# Altium schematic sheet DE-120824.SchDoc(DE-120824)
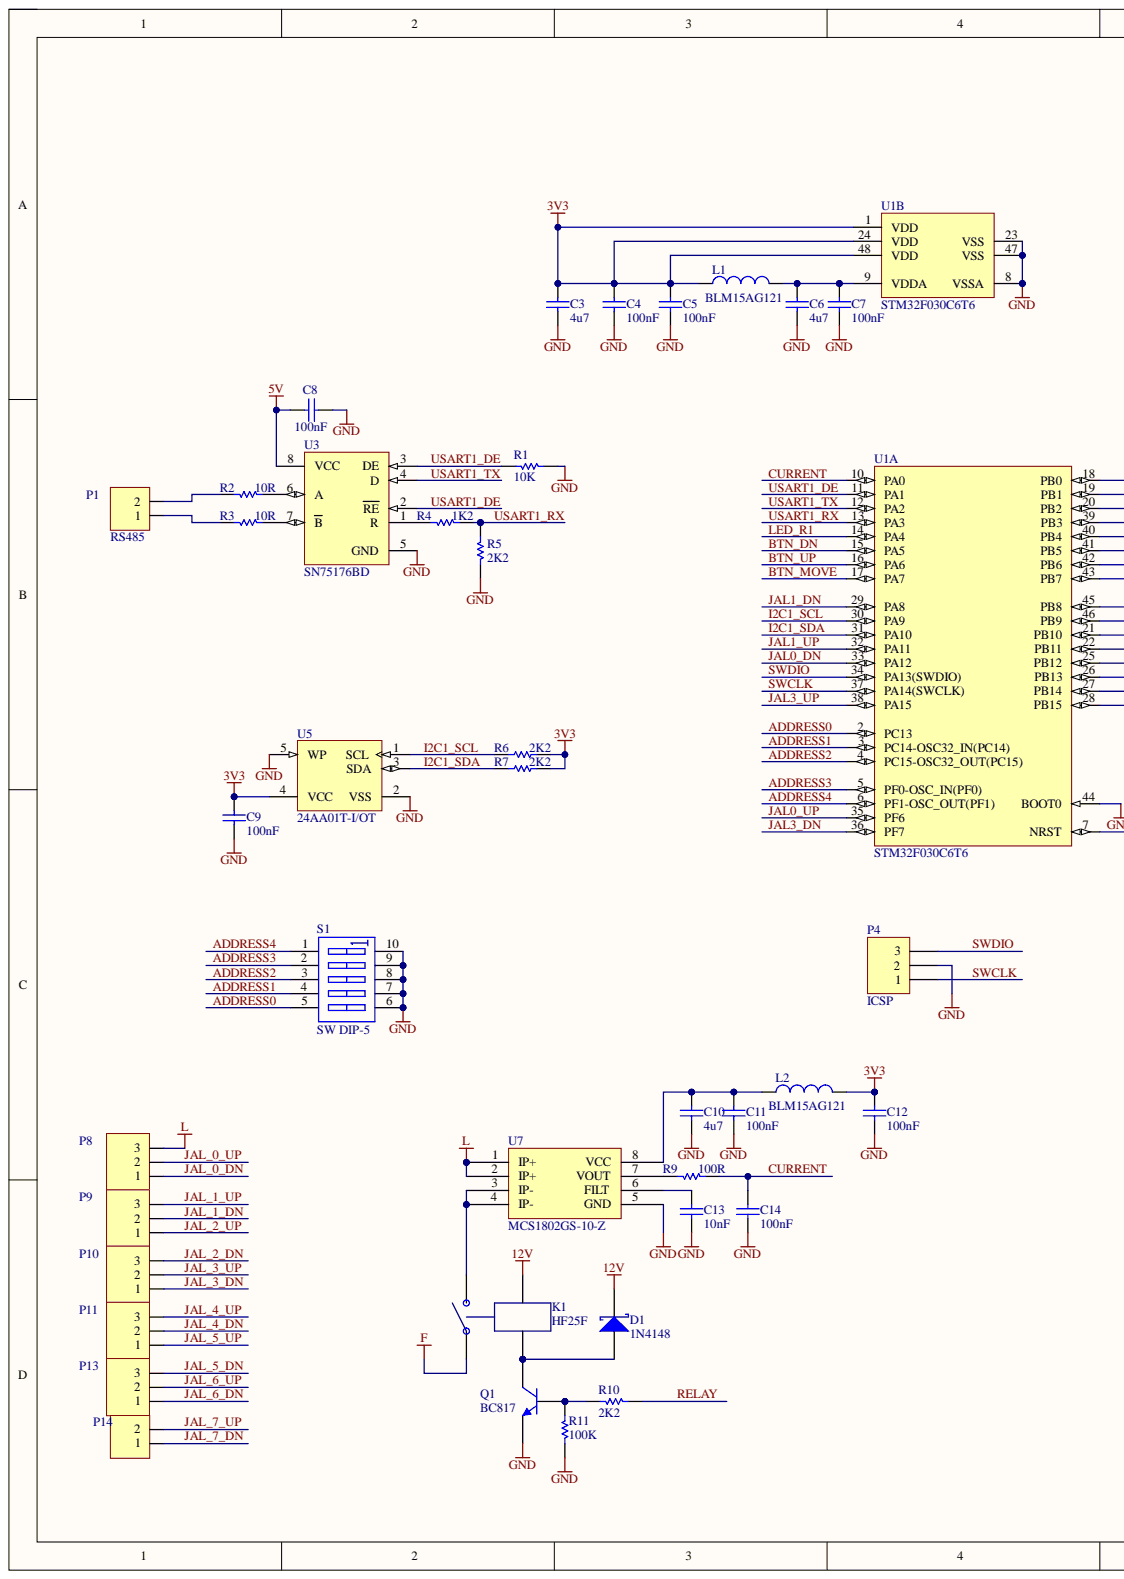
[diagram p0: DE-120824.SchDoc, left]
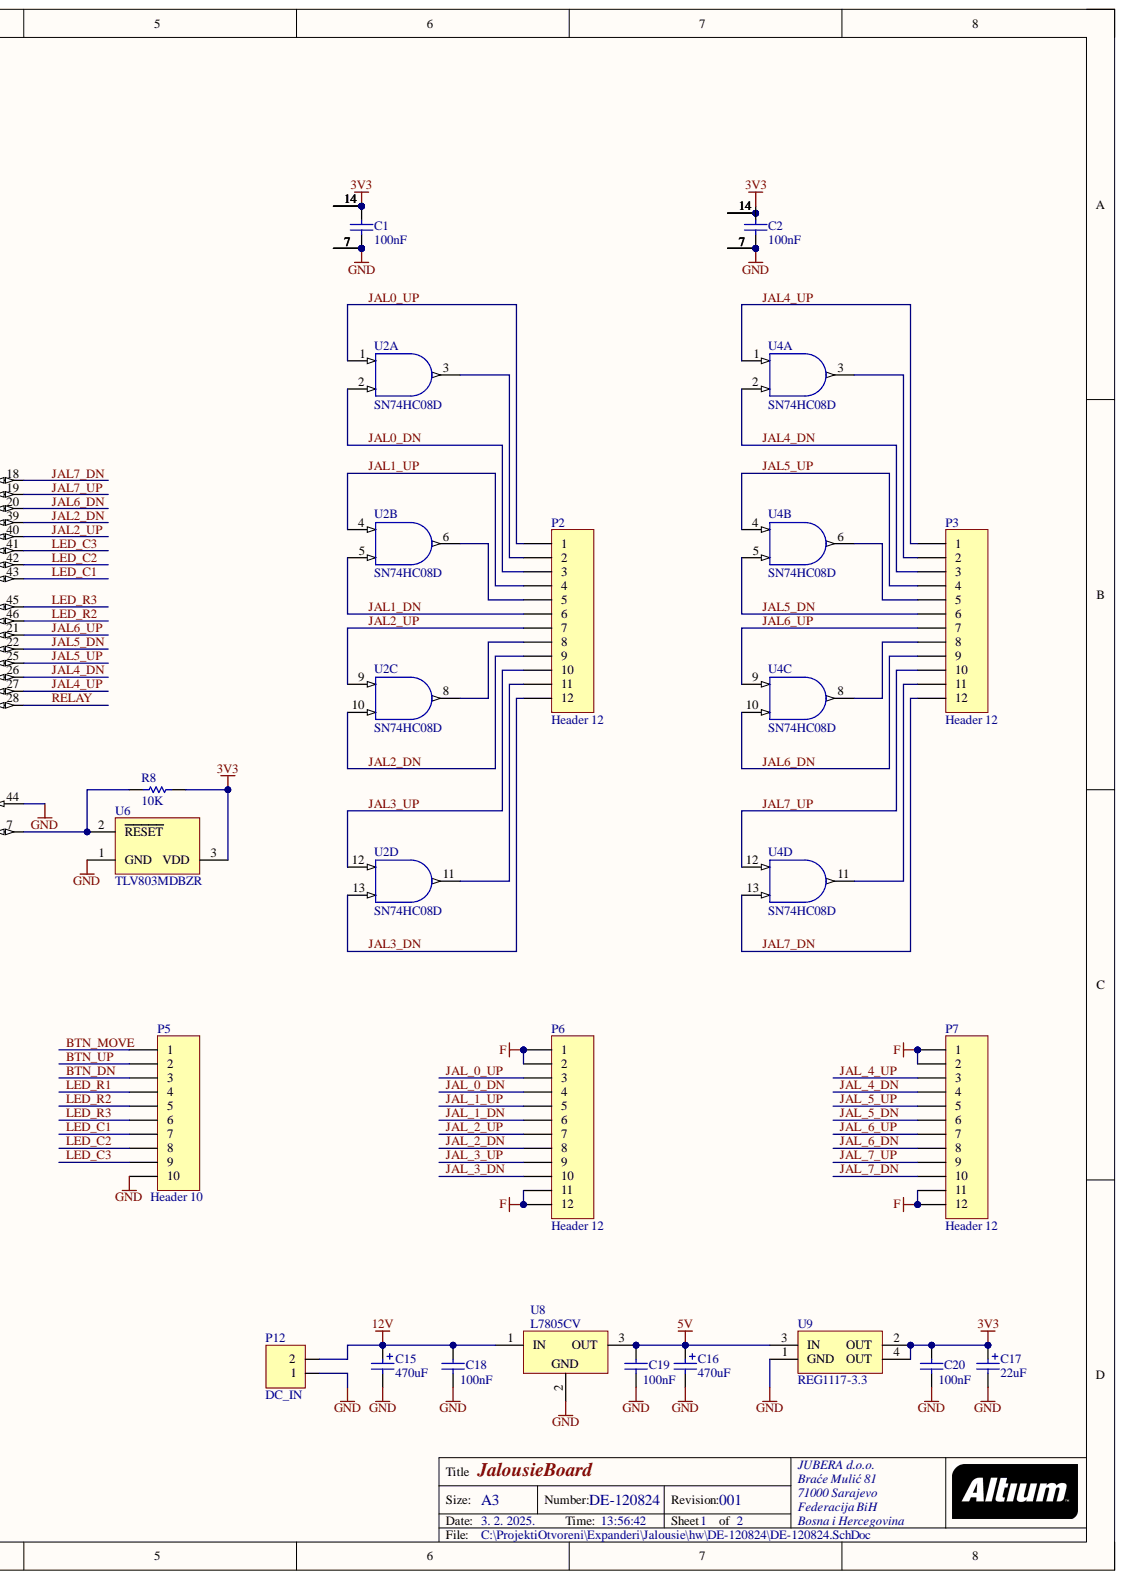
[diagram p1: DE-120824.SchDoc, right]

## Parts
C1  value 100nF  [0603]  (hidden comment: C0603)
C2  value 100nF  [0603]  (hidden comment: C0603)
C3  value 4u7  [0805]  (hidden comment: C0805)
C4  value 100nF  [0603]  (hidden comment: C0603)
C5  value 100nF  [0603]  (hidden comment: C0603)
C6  value 4u7  [0805]  (hidden comment: C0805)
C7  value 100nF  [0603]  (hidden comment: C0603)
C8  100nF  [0603]
C9  value 100nF  [0603]  (hidden comment: C0603)
C10  value 4u7  [0805]  (hidden comment: C0805)
C11  value 100nF  [0603]  (hidden comment: C0603)
C12  value 100nF  [0603]  (hidden comment: C0603)
C13  value 10nF  [0603]  (hidden comment: C0603)
C14  value 100nF  [0603]  (hidden comment: C0603)
C15  470uF  [CAPPR5-10X12.5]
C16  470uF  [CAPPR5-10X12.5]
C17  22uF  [CAPPR2-5X11]
C18  100nF  [0603]
C19  100nF  [0603]
C20  100nF  [0603]
D1  1N4148  [SOD323]
K1  HF25F  [HF25F]
L1  BLM15AG121  [0402-A]
L2  BLM15AG121  [0402-A]
P1  RS485  [TERMINAL BLOK 2]
P2  Header 12  [HDR1X12]
P3  Header 12  [HDR1X12]
P4  ICSP  [HDR1X3]
P5  Header 10  [HDR1X10]
P6  Header 12  [HDR1X12]
P7  Header 12  [HDR1X12]
P8  (no value)  [TERMINAL BLOK 3]
P9  (no value)  [TERMINAL BLOK 3]
P10  (no value)  [TERMINAL BLOK 3]
P11  (no value)  [TERMINAL BLOK 3]
P12  DC_IN  [TERMINAL BLOK 2]
P13  (no value)  [TERMINAL BLOK 3]
P14  (no value)  [TERMINAL BLOK 2]
Q1  BC817  [SOT23_N]
R1  10K  [ERA-0603]
R2  10R  [ERA-0603]
R3  10R  [ERA-0603]
R4  1K2  [ERA-0603]
R5  2K2  [ERA-0603]
R6  2K2  [ERA-0603]
R7  2K2  [ERA-0603]
R8  10K  [ERA-0603]
R9  100R  [ERA-0603]
R10  2K2  [ERA-0603]
R11  100K  [ERA-0603]
S1  SW DIP-5  [N10A]
U1  STM32F030C6T6  [STM-LQFP48_N]  (parts A,B)
U2  SN74HC08D  [D014_N]  (parts A,B,C,D)
U3  SN75176BD  [D008_N]
U4  SN74HC08D  [D014_N]  (parts A,B,C,D)
U5  24AA01T-I/OT  [SOT-23-OT5_N]
U6  TLV803MDBZR  [DBZ3_N]
U7  MCS1802GS-10-Z  [SOIC-SN8_N]
U8  L7805CV  [TO220]
U9  REG1117-3.3  [ZZ311]

## Nets
3V3: C1.1 C2.1 C3.2 C4.2 C5.2 C9.2 C12.2 C17.1 C20.2 L1.1 L2.2 R6.2 R7.2 R8.2 U1.1(VDD) U1.24(VDD) U1.48(VDD) U2.14 U5.4(VCC) U6.3 U9.2(OUT) U9.4(OUT)
5V: C8.1 C16.1 C19.2 U3.8(VCC) U8.3 U9.3(IN)
12V: C15.1 C18.2 D1.1 K1.2 P12.2 U8.1
F: K1.3 P6.1 P6.2 P6.11 P6.12 P7.1 P7.2 P7.11 P7.12
GND: C1.2 C2.2 C3.1 C4.1 C5.1 C6.1 C7.1 C8.2 C9.1 C10.1 C11.1 C12.1 C13.1 C14.1 C15.2 C16.2 C17.2 C18.1 C19.1 C20.1 P4.2 P5.10 P12.1 Q1.2 R1.1 R5.2 R11.1 S1.6 S1.7 S1.8 S1.9 S1.10 U1.8(VSSA) U1.23(VSS) U1.44(BOOT0) U1.47(VSS) U2.7 U3.5(GND) U4.7 U5.2(VSS) U5.5(WP) U6.1 U7.5(GND) U8.2 U9.1(GND)
L: P8.3 U7.1(IP+) U7.2(IP+)
ADDRESS0: S1.5 U1.2(PC13)
ADDRESS1: S1.4 U1.3(PC14-OSC32_IN(PC14))
ADDRESS2: S1.3 U1.4(PC15-OSC32_OUT(PC15))
ADDRESS3: S1.2 U1.5(PF0-OSC_IN(PF0))
ADDRESS4: S1.1 U1.6(PF1-OSC_OUT(PF1))
BTN_DN: P5.3 U1.15(PA5)
BTN_MOVE: P5.1 U1.17(PA7)
BTN_UP: P5.2 U1.16(PA6)
CURRENT: C14.2 R9.1 U1.10(PA0)
I2C1_SCL: R6.1 U1.30(PA9) U5.1(SCL)
I2C1_SDA: R7.1 U1.31(PA10) U5.3(SDA)
JAL0_DN: P2.3 U1.33(PA12) U2.2
JAL0_UP: P2.1 U1.35(PF6) U2.1
JAL1_DN: P2.6 U1.29(PA8) U2.5
JAL1_UP: P2.4 U1.32(PA11) U2.4
JAL2_DN: P2.9 U1.39(PB3) U2.10
JAL2_UP: P2.7 U1.40(PB4) U2.9
JAL3_DN: P2.12 U1.36(PF7) U2.13
JAL3_UP: P2.10 U1.38(PA15) U2.12
JAL4_DN: P3.3 U1.26(PB13) U4.2
JAL4_UP: P3.1 U1.27(PB14) U4.1
JAL5_DN: P3.6 U1.22(PB11) U4.5
JAL5_UP: P3.4 U1.25(PB12) U4.4
JAL6_DN: P3.9 U1.20(PB2) U4.10
JAL6_UP: P3.7 U1.21(PB10) U4.9
JAL7_DN: P3.12 U1.18(PB0) U4.13
JAL7_UP: P3.10 U1.19(PB1) U4.12
JAL_0_DN: P6.4 P8.1
JAL_0_UP: P6.3 P8.2
JAL_1_DN: P6.6 P9.2
JAL_1_UP: P6.5 P9.3
JAL_2_DN: P6.8 P10.3
JAL_2_UP: P6.7 P9.1
JAL_3_DN: P6.10 P10.1
JAL_3_UP: P6.9 P10.2
JAL_4_DN: P7.4 P11.2
JAL_4_UP: P7.3 P11.3
JAL_5_DN: P7.6 P13.3
JAL_5_UP: P7.5 P11.1
JAL_6_DN: P7.8 P13.1
JAL_6_UP: P7.7 P13.2
JAL_7_DN: P7.10 P14.1
JAL_7_UP: P7.9 P14.2
LED_C1: P5.7 U1.43(PB7)
LED_C2: P5.8 U1.42(PB6)
LED_C3: P5.9 U1.41(PB5)
LED_R1: P5.4 U1.14(PA4)
LED_R2: P5.5 U1.46(PB9)
LED_R3: P5.6 U1.45(PB8)
RELAY: R10.2 U1.28(PB15)
SWCLK: P4.1 U1.37(PA14(SWCLK))
SWDIO: P4.3 U1.34(PA13(SWDIO))
USART1_DE: R1.2 U1.11(PA1) U3.2(RE) U3.3(DE)
USART1_RX: R4.1 R5.1 U1.13(PA3)
USART1_TX: U1.12(PA2) U3.4(D)
NetC6_2: C6.2 C7.2 L1.2 U1.9(VDDA)
NetC10_2: C10.2 C11.2 L2.1 U7.8(VCC)
NetC13_2: C13.2 U7.6(FILT)
NetD1_2: D1.2 K1.1 Q1.3
NetK1_4: K1.4 U7.3(IP-) U7.4(IP-)
NetP1_1: P1.1 R3.1
NetP1_2: P1.2 R2.1
NetP2_2: P2.2 U2.3
NetP2_5: P2.5 U2.6
NetP2_8: P2.8 U2.8
NetP2_11: P2.11 U2.11
NetP3_2: P3.2 U4.3
NetP3_5: P3.5 U4.6
NetP3_8: P3.8 U4.8
NetP3_11: P3.11 U4.11
NetQ1_1: Q1.1 R10.1 R11.2
NetR2_2: R2.2 U3.6(A)
NetR3_2: R3.2 U3.7(B)
NetR4_2: R4.2 U3.1(R)
NetR8_1: R8.1 U1.7(NRST) U6.2
NetR9_2: R9.2 U7.7(VOUT)

Not connected: U4 14

## Library data of the parts
C1 C2 C4 C5 C7-C9 C11-C14 C18-C20 = C0603: Ceramic Chip Capacitor - Standard; Note: Footprint corresponds to dimensions for bulk cassette packaging. This case size has tightened tolerances.; Code_IPC: 1608 [0603]; Code_JEITA: 0603; Component Kind: Standard; ComponentLink1Description: Manufacturer Link; ComponentLink1URL: http://www.kemet.com/; ComponentLink2Description: Datasheet; ComponentLink2URL: http://www.kemet.com/kemet/web/homepage/kechome.nsf/vapubfilesname/F3102TA.pdf/$file/F3102TA.pdf; DatasheetDocument: Mar-2001; LatestRevisionDate: 19-Mar-2004; LatestRevisionNote: Silkscreen size reduced.; PackageDocument: Mar-2001; PackageReference: 0603; Published: 17-Jul-2002; Signal Integrity: C0603; Simulation: CAP; Publisher: Altium Limited
  C1 C2 C4 C5 C7 C9 C11-C14: Library Name: DE-120824.SchLib
  C8 C18-C20: Library Name: DE-220817.SchLib; Value: 100nF
C3 C6 C10 = C0805: Ceramic Chip Capacitor - Standard; Note: Footprint corresponds to dimensions for bulk cassette packaging. This case size has tightened tolerances.; Code_IPC: 2012[0805]; Code_JEITA: 0805; Component Kind: Standard; ComponentLink1Description: Manufacturer Link; ComponentLink1URL: http://www.kemet.com/; ComponentLink2Description: Datasheet; ComponentLink2URL: http://www.kemet.com/kemet/web/homepage/kechome.nsf/vapubfilesname/F3102TA.pdf/$file/F3102TA.pdf; DatasheetDocument: Mar-2001; LatestRevisionDate: 19-Mar-2004; LatestRevisionNote: Silkscreen size reduced.; Library Name: DE-120824.SchLib; PackageDocument: Mar-2001; PackageReference: 0805; Published: 17-Jul-2002; Signal Integrity: C0805; Simulation: CAP; Publisher: Altium Limited
C15 C16 = EKA00DC347E00: Aluminum Electrolytic Capacitor, Radial Style with Long Leads: 470uF, 25V; DrillSize: Nominal, Rounded To The Nearest 0.1mm; Note: Refer to Manufacturer's Data Sheets for other lead formations; Component Kind: Standard; ComponentLink1Description: Manufacturer Link; ComponentLink1URL: http://www.vishay.com/; ComponentLink2Description: Datasheet; ComponentLink2URL: http://www.vishay.com/docs/25014/eka.pdf; DatasheetDocument: 20-Feb-2001; Library Name: DE-010824.SchLib; PackageReference: CAPPR5-10X12.5; Published: 19-Mar-2004; Signal Integrity: EKA00DC347E00; Simulation: CAP; Value: 470uF; Publisher: Altium Limited
C17 = EKA00AA247F00: Aluminum Electrolytic Capacitor, Radial Style with Long Leads: 47uF, 35V; DrillSize: Nominal, Rounded To The Nearest 0.1mm; Note: Refer to Manufacturer's Data Sheets for other lead formations; Component Kind: Standard; ComponentLink1Description: Manufacturer Link; ComponentLink1URL: http://www.vishay.com/; ComponentLink2Description: Datasheet; ComponentLink2URL: http://www.vishay.com/docs/25014/eka.pdf; DatasheetDocument: 20-Feb-2001; Library Name: DE-010824.SchLib; PackageReference: CAPPR2-5X11; Published: 19-Mar-2004; Signal Integrity: EKA00AA247F00; Simulation: CAP; Value: 47uF; Publisher: Altium Limited
D1 = 1PS76SB10: Schottky Barrier Diode; Component Kind: Standard; ComponentLink1Description: Manufacturer Link; ComponentLink1URL: http://www.semiconductors.philips.com/; ComponentLink2Description: Datasheet; ComponentLink2URL: http://www.semiconductors.philips.com/acrobat/datasheets/1PS76SB10_2.pdf; DatasheetVersion: 14-Oct-1996; LatestRevisionDate: 19-Mar-2004; LatestRevisionNote: Silkscreen size reduced.; Library Name: DE-120824.SchLib; PackageDescription: SOD-323/SC-76; 2 Leads; Body 1.7 x 1.3 mm, (LxW); PackageReference: SOD323; PackageVersion: May-1999; Published: 17-Sep-2003; Signal Integrity: 1PS76SB10; Simulation: D1PS76SBD10; Publisher: Altium Limited
K1 = HF25F: PCB relay 250VAC/20A 30VDC/16A; Component Kind: Standard; Library Name: DE-120824.SchLib
L1 L2 = Chip inductor: SMD chip inductor; Code_IEC: 1005; Code_JEITA: 0402; Component Kind: Standard; LatestRevisionDate: 29-May-2009; LatestRevisionNote: IPC-7351 Footprint Added.; Library Name: DE-120824.SchLib; PackageDescription: Chip Inductor; PackageReference: 0402-A; Published: 8-Jun-2000; Signal Integrity: Inductor; Simulation: INDUCTOR; Publisher: Altium Limited
P1 P12 P14 = TERMINAL BLOK 2: Terminal blok konektor 3,5 mm; Component Kind: Standard
  P1 P14: Library Name: DE-120824.SchLib
  P12: Library Name: DE-010824.SchLib
P2 P3 P6 P7 = Header 12: Header, 12-Pin; Component Kind: Standard; LatestRevisionDate: 17-Jul-2002; LatestRevisionNote: Re-released for DXP Platform.; Library Name: Miscellaneous Connectors.IntLib; Signal Integrity: Connector; Publisher: Altium Limited
P4 = Header 3: Header, 3-Pin; Component Kind: Standard; LatestRevisionDate: 17-Jul-2002; LatestRevisionNote: Re-released for DXP Platform.; Library Name: Miscellaneous Connectors.IntLib; Signal Integrity: Connector; Publisher: Altium Limited
P5 = Header 10: Header, 10-Pin; Component Kind: Standard; LatestRevisionDate: 17-Jul-2002; LatestRevisionNote: Re-released for DXP Platform.; Library Name: Miscellaneous Connectors.IntLib; Signal Integrity: Connector; Publisher: Altium Limited
P8-P11 P13 = TERMINAL BLOK 3: 3 pinski terminal blok konektor; Component Kind: Standard; Library Name: DE-120824.SchLib
Q1 = BC817: NPN General-purpose Transistor; Component Kind: Standard; ComponentLink1Description: Manufacturer Link; ComponentLink1URL: http://www.semiconductors.philips.com/; ComponentLink2Description: Datasheet; ComponentLink2URL: http://www.semiconductors.philips.com/acrobat/datasheets/BC817_BC817W_BC337_5.pdf; DatasheetVersion: Jun-1999; LatestRevisionDate: 12-Dec-2006; LatestRevisionNote: IPC-7351 Footprint Added.; Library Name: DE-120824.SchLib; PackageDescription: 3-Pin SOT-23 Package 0.95 mm Pitch, 2.3 mm Lead Span; PackageReference: SOT23; PackageVersion: May-1999; Published: 17-Sep-2003; Signal Integrity: BC817; Simulation: QBC817; Publisher: Altium Limited
R1-R5 R9-R11 = ERAV: Metal Film Thermosensitive Chip Resistor, 0.56 Ohm to 10k Ohm Range, 5% Tolerance, 0603 Size, 0.063 W; Note: If flow soldering, adjust pad width of PCB Footprint to 0.7 - 0.8 times the width of the resistor.; Code_IPC: 1608 [0603]; Component Kind: Standard; ComponentLink1Description: Manufacturer Link; ComponentLink1URL: http://www.panasonic.com/industrial/components/resistive_products.htm; ComponentLink2Description: Datasheet; ComponentLink2URL: http://www.panasonic.com/industrial/components/pdf/AOF0000CE1.pdf; LatestRevisionDate: 19-Mar-2004; LatestRevisionNote: Silkscreen size reduced.; Library Name: DE-220817.SchLib; PackageDocument: Mar-2003; PackageReference: ERA-0603; Published: 17-Dec-2003; Signal Integrity: Res; Simulation: RESISTOR; Publisher: Altium Limited
R6-R8 = ERA3Y: Rectangular Thick Film Chip Resistor, 10 Ohm to 330k Ohm Range, 0.1% and 0.5% Tolerance, 0603 Size, 0.063 W; Note: If flow soldering, adjust pad width of PCB Footprint to 0.7 - 0.8 times the width of the resistor.; Code_IPC: 1608 [0603]; Component Kind: Standard; ComponentLink1Description: Manufacturer Link; ComponentLink1URL: http://www.panasonic.com/industrial/components/resistive_products.htm; ComponentLink2Description: Datasheet; ComponentLink2URL: http://www.panasonic.com/industrial/components/pdf/AOA0000CE8.pdf; LatestRevisionDate: 19-Mar-2004; LatestRevisionNote: Silkscreen size reduced.; Library Name: DE-120824.SchLib; PackageDocument: Mar-2003; PackageReference: ERA-0603; Published: 17-Dec-2003; Signal Integrity: Res; Simulation: RESISTOR; Publisher: Altium Limited
S1 = SW DIP-5: DIP Switch, 5 Position, SPST; Component Kind: Standard; LatestRevisionDate: 17-Jul-2002; LatestRevisionNote: Re-released for DXP Platform.; Library Name: Miscellaneous Devices.IntLib; PackageReference: N10A; Published: 24-Mar-1999; Simulation: dpsw5; Publisher: Altium Limited
U1 = STM32F030C6T6: ARM Cortex-M0 32-bit MCU, 32 KB Flash, 4 KB Internal RAM, 39 I/Os, 48-pin LQFP, -40 to 85 degC, Tray; FLASH Size (Prog) (kB): 32; I/Os (High Current): 39; Internal RAM Size (kB): 4; Operating Frequency(F) (Processor speed) (MHz): 48; Supply Voltage(Vcc) min (V): 2.4; Component Kind: Standard; ComponentLink1Description: Manufacturer URL; ComponentLink1URL: http://www.st.com/web/en/home.html; ComponentLink2Description: Datasheet; ComponentLink2URL: http://www.st.com/st-web-ui/static/active/en/resource/technical/document/datasheet/DM00088500.pdf; ComponentLink3Description: Package Specification; ComponentLink3URL: http://www.st.com/st-web-ui/static/active/en/resource/technical/document/datasheet/DM00058181.pdf; Core: ARM Cortex-M0; DatasheetVersion: Rev. 1; Library Name: STMicroelectronics STM32 F0.IntLib; Mounting Technology: Surface Mount; PackageDescription: 48-Pin Low Profile Quad Flat Package, 7 x 7 mm Body, 0.5 mm Pitch; PackageReference: LQFP48; PackageVersion: Rev. 6, 06/2013; Packing: Tray; PartNumber: STM32F030C6T6; Supplier 1: Digi-Key; Supplier 2: Newark; Supplier 3: Mouser; Supplier Part Number 1: 497-14042-ND; Supplier Part Number 2: 06X3646; Supplier Part Number 3: 511-STM32F030C6T6
U2 U4 = SN74HC08D: Quadruple 2-Input Positive-AND Gate; Code_IPC: SOIC127P600-14; Component Kind: Standard; ComponentLink1Description: Manufacturer Link; ComponentLink1URL: http://www.ti.com/; ComponentLink2Description: Datasheet; ComponentLink2URL: http://www-s.ti.com/sc/ds/SN74HC08.pdf; DatasheetVersion: May-1997; LatestRevisionDate: 13-Apr-2006; LatestRevisionNote: IPC-7351 Footprint Added.; Library Name: TI Logic Gate 2.IntLib; PackageDescription: 14-Pin Small Outline Integrated Circuit 1.27 mm Pitch; PackageReference: D014; PackageVersion: Jan-1998; PCB3D: D014; Published: 8-Jun-2000; Signal Integrity: SN74HC08D; Publisher: Altium Limited
U3 = SN75176BD: Differential Bus Transceiver; Note: Receiver Hysteresis of 50mV Typical; Code_IPC: SOIC127P600-8; Component Kind: Standard; ComponentLink1Description: Manufacturer Link; ComponentLink1URL: http://www.ti.com/; ComponentLink2Description: Datasheet; ComponentLink2URL: http://www-s.ti.com/sc/ds/sn75176b.pdf; DatasheetVersion: Sep-1989; LatestRevisionDate: 13-Apr-2006; LatestRevisionNote: IPC-7351 Footprint Added.; Library Name: DE-010824.SchLib; PackageDescription: 8-Pin Small Outline Integrated Circuit 1.27 mm Pitch; PackageReference: D008; PackageVersion: Jan-1998; PCB3D: D008; Published: 15-May-2002; Publisher: Altium Limited
U5 = 24AA01T-I/OT: 1Kbit, 400kHz, 1.8V, I2C Serial EEPROM, 5-Pin SOT-23, Industrial Temperature, Tape and Reel; Code_IPC: SOT23-5; Component Kind: Standard; ComponentLink1Description: Datasheet; ComponentLink1URL: http://ww1.microchip.com/downloads/en/DeviceDoc/21711J.pdf; ComponentLink2Description: Packaging Specification; ComponentLink2URL: http://www.microchip.com/stellent/groups/techpub_sg/documents/packagingspec/en012702.pdf; ComponentLink3Description: Microchip.com Home; ComponentLink3URL: http://www.microchip.com/; DatasheetVersion: revJ, Jan-2009; Library Name: Microchip Memory I2C Serial EEPROM.IntLib; PackageDescription: 5-Lead Plastic Small Outline Transistor (OT) [SOT-23]; PackageReference: SOT-23-OT5; PackageVersion: revBB, Aug-2009; Published: 29-Jan-2009; Supplier 1: Digi-Key; Supplier 2: Digi-Key; Supplier 3: Digi-Key; Supplier 4: Farnell; Supplier Part Number 1: 24AA01T-I/OTTR-ND; Supplier Part Number 2: 24AA01T-I/OTCT-ND; Supplier Part Number 3: 24AA01T-I/OTDKR-ND; Supplier Part Number 4: 1331269; Publisher: Altium Limited
U6 = TLV803MDBZR: Voltage Supervisor with Active-Low, Open Drain Reset, 1 Supply Monitored, -40 to 125 degC, 3-pin SOT-23 (DBZ), Green (RoHS & no Sb/Br); Manufacturer: Texas Instruments; # of supplies monitored: 1; Iq(Typ)(uA): 9; Output Driver Type / Reset Output: Active-low, Open-drain; Rating: Catalog; Reset Threshold Accuracy(%): 2; SubFamily: Supervisor and Reset IC; Threshold Voltage 1 (typ)(Typ)(V): 4.38; Time Delay(ms): 200; VCC(Max)(V): 6; VCC(Min)(V): 1.1; Code_JEDEC: TO-236-AB; Component Kind: Standard; ComponentLink1Description: Datasheet; ComponentLink1URL: http://www.ti.com/general/docs/lit/getliterature.tsp?genericPartNumber=TLV803M&fileType=pdf; DatasheetVersion: SBVS157B; Library Name: TI Power Management Supervisor and Reset IC.IntLib; Mounting Technology: Surface Mount; PackageDescription: 3-Pin Plastic Small-Outline Package, 0.95 mm Pitch, 2.4 mm Lead Span; PackageReference: DBZ3; PackageVersion: revB, Apr-2005; PartNumber: TLV803MDBZR; Supplier 1: Digi-Key; Supplier 2: Digi-Key; Supplier 3: Digi-Key; Supplier 4: Newark; Supplier 5: Mouser; Supplier Part Number 1: 296-29194-2-ND; Supplier Part Number 2: 296-29194-1-ND; Supplier Part Number 3: 296-29194-6-ND; Supplier Part Number 4: 58T0286; Supplier Part Number 5: 595-TLV803MDBZR
U7 = ACS71240: Component Kind: Standard; Library Name: DE-120824.SchLib
U8 = L7805CV: Positive Voltage Regulator, 5V, 3-Pin TO-220; Component Kind: Standard; ComponentLink1Description: Manufacturer URL; ComponentLink1URL: http://www.st.com; ComponentLink2Description: Datasheet; ComponentLink2URL: http://www.st.com/internet/com/TECHNICAL_RESOURCES/TECHNICAL_LITERATURE/DATASHEET/CD00000444.pdf; ComponentLink3Description: Package Specification; ComponentLink3URL: http://www.st.com/internet/com/TECHNICAL_RESOURCES/TECHNICAL_LITERATURE/PACKAGE_INFORMATION/CD00004649.pdf; DatasheetVersion: Nov-2011; Library Name: DE-010824.SchLib; PackageDescription: TO, Thru-Hole, Vertical, Heatsink Mounted; 3 In-Line Leads; Pitch 2.54 mm; PackageReference: TO220; PackageVersion: ver3.0; PartNumber: L7805CV
U9 = REG1117-3.3: 800mA and 1A Low Dropout Positive Regulator 2.85V, 3.3V, 5V, and Adjustable; Component Kind: Standard; ComponentLink1Description: Manufacturer Link; ComponentLink1URL: http://www.ti.com/; ComponentLink2Description: Datasheet; ComponentLink2URL: http://www-s.ti.com/sc/ds/REG1117.pdf; DatasheetVersion: Sep-1997; LatestRevisionDate: 17-Jul-2002; LatestRevisionNote: Re-released for DXP Platform.; Library Name: DE-010824.SchLib; PackageDescription: SOT-223/SC-73; 4 Leads; Body 6.7 x 7.3 mm, inc. leads (LxW max); PackageReference: ZZ311; PackageVersion: revC; Published: 8-Jun-2000; Publisher: Altium Limited
